# Altium schematic sheet ADC.SchDoc(ADC)
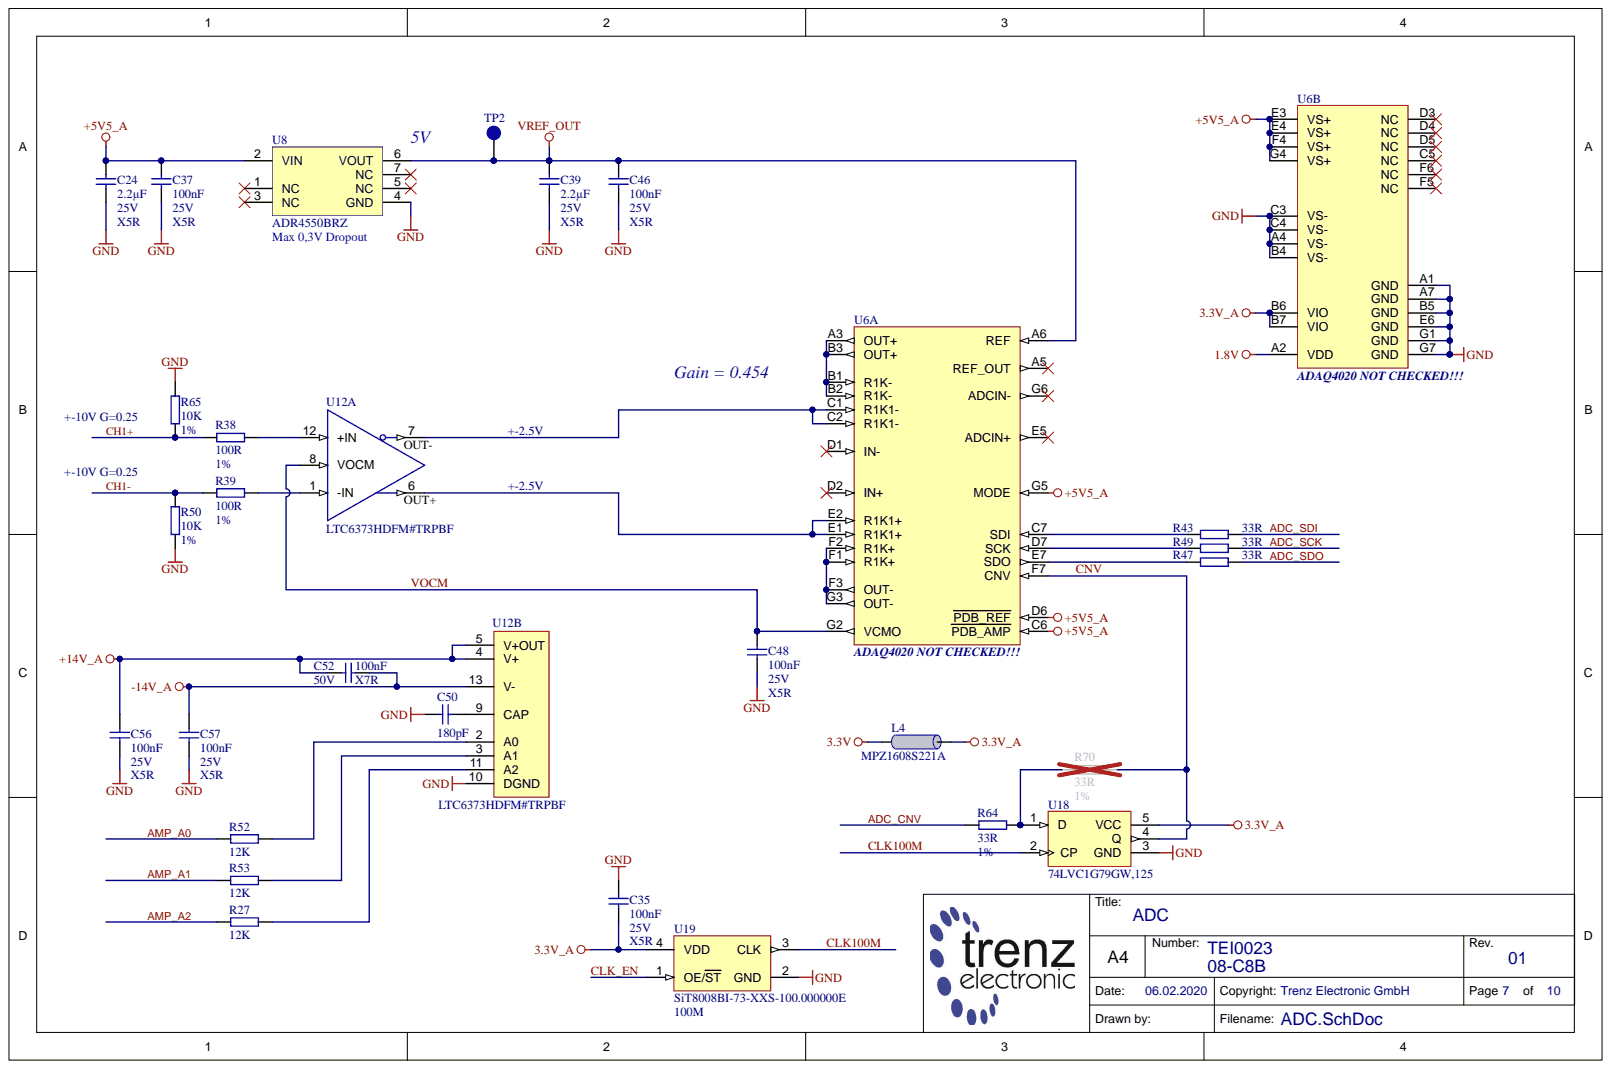
[diagram p0: ADC.SchDoc]

## Parts
C24  2.2µF  Voltage: 25V  Technology: X5R  [CAPC1608X90N]
C35  100nF  Voltage: 25V  [CAPC1005X55N]
C37  100nF  Voltage: 25V  Technology: X5R  [CAPC1005X55N]
C39  2.2µF  Voltage: 25V  Technology: X5R  [CAPC1608X90N]
C46  100nF  Voltage: 25V  Technology: X5R  [CAPC1005X55N]
C48  100nF  Voltage: 25V  Technology: X5R  [CAPC1005X55N]
C50  180pF  [CAPC1005X55N]
C52  100nF  Voltage: 50V  Technology: X7R  [CAPC1005X55N]
C56  100nF  Voltage: 25V  Technology: X5R  [CAPC1005X55N]
C57  100nF  Voltage: 25V  Technology: X5R  [CAPC1005X55N]
L4  MPZ1608S221A  [INDC1608X95N]
R27  12K  [RESC1005X40N]
R38  100R  Tolerance: 1%  [RESC0603X26N]
R39  100R  Tolerance: 1%  [RESC0603X26N]
R43  33R  [RESC1005X40N]
R47  33R  [RESC1005X40N]
R49  33R  [RESC1005X40N]
R50  10K  Tolerance: 1%  [RESC1005X40N]
R52  12K  [RESC1005X40N]
R53  12K  [RESC1005X40N]
R64  33R  Tolerance: 1%  [RESC1005X40N]
R65  10K  Tolerance: 1%  [RESC1005X40N]
R70  33R  [RESC1005X40N]
TP2  Testpoint 0.8mm  [TP_0.8mm]  (value not drawn)
U6  ADAQ4020 NOT CHECKED!!!  [BGA49C80P7X7_700X700X243B45N]  (parts A,B)
U6  ADAQ4020 NOT CHECKED!!!  [BGA49C80P7X7_700X700X243B45N]  (part B)
U8  ADR4550BRZ  Voltage: 5V  [SOIC127P600X175-8N]
U12  LTC6373HDFM#TRPBF  [SON80P400X400X80-13T]  (parts A,B)
U18  74LVC1G79GW,125  [SOT65P210X110-5N]
U19  SiT8008BI-73-XXS-100.000000E  value 100M  Database Table Name: CLK  [2016]

## Nets
1.8V: U6.A2
3.3V: L4.1
3.3V_A: C35.1 L4.2 U6.B6 U6.B7 U18.5(VCC) U19.4(VDD)
+5V5_A: C24.1 C37.1 U6.C6(PDB_AMP) U6.D6(PDB_REF) U6.E3 U6.E4 U6.F4 U6.G4 U6.G5(MODE) U8.2(VIN)
+14V_A: C52.1 C56.1 U12.4(V+) U12.5(V+OUT)
-14V_A: C52.2 C57.1 U12.13(V-)
GND: C24.2 C35.2 C37.2 C39.2 C46.2 C48.2 C50.1 C56.2 C57.2 R50.1 R65.2 U6.A1 U6.A4 U6.A7 U6.B4 U6.B5 U6.C3 U6.C4 U6.E6 U6.G1 U6.G7 U8.4(GND) U12.10(DGND) U18.3(GND) U19.2(GND)
VREF_OUT: C39.1 C46.1 TP2.1 U6.A6(REF) U8.6(VOUT)
ADC_CNV: R64.2
ADC_SCK: R49.2
ADC_SDI: R43.2
ADC_SDO: R47.2
AMP_A0: R52.1
AMP_A1: R53.1
AMP_A2: R27.1
CH1+: R38.1 R65.1
CH1-: R39.1 R50.2
CLK100M: U18.2(CP) U19.3(CLK)
CLK_EN: U19.1(OE/ST)
CNV: R70.1 U6.F7(CNV) U18.4(Q)
VOCM: C48.1 U6.G2(VCMO) U12.8(VOCM)
NetC50_2: C50.2 U12.9(CAP)
NetR27_2: R27.2 U12.11(A2)
NetR38_2: R38.2 U12.12(+IN)
NetR39_2: R39.2 U12.1(-IN)
NetR43_1: R43.1 U6.C7(SDI)
NetR47_1: R47.1 U6.E7(SDO)
NetR49_1: R49.1 U6.D7(SCK)
NetR52_2: R52.2 U12.2(A0)
NetR53_2: R53.2 U12.3(A1)
NetR64_1: R64.1 R70.2 U18.1(D)
NetU6_A3: U6.A3(OUT+) U6.B1(R1K-) U6.B2(R1K-) U6.B3(OUT+)
NetU6_C1: U6.C1(R1K1-) U6.C2(R1K1-) U12.7
NetU6_E1: U6.E1(R1K1+) U6.E2(R1K1+) U12.6
NetU6_F1: U6.F1(R1K+) U6.F2(R1K+) U6.F3(OUT-) U6.G3(OUT-)

Not connected: U6 A5(REF_OUT) C5 D1(IN-) D2(IN+) D3 D4 D5 E5(ADCIN+) F5 F6 G6(ADCIN-); U8 1(NC) 3(NC) 5(NC) 7(NC)

## Library data of the parts
C24 C39 = 27910: KON 2.2uF 25V X5R ±10% SMD BF 1608 (0603); Value: 2.2u; Temperature-Range: -55/+85; Mixed: SMT; Database Table Name: C_1608_0603; Tolerance: ±10%; Library Name: TE.DbLib; Component Kind: Standard; Case: 1608 (0603); AN: 27910
C35 C37 C46 C48 C56 C57 = 22472: KON 100nF 25V X5R ±10% SMD BF 1005 (0402); Value: 100n; Temperature-Range: -55/+85; Preferred: Yes; Mixed: SMT; Database Table Name: C_1005_0402; Library Name: TE.DbLib; Component Kind: Standard; Case: 1005 (0402); AN: 22472
  C35: Technology: X5R
C50 = 24855: KON 180pF 50V NP0 SMD BF 1005 (0402); Value: 180p; Mixed: SMT; Database Table Name: C_1005_0402; Voltage: 50V; Technology: NP0; Library Name: TE.DbLib; Component Kind: Standard; Case: 1005 (0402); AN: 24855
C52 = 22651: KON 100nF 50V X7R ±10% SMD BF 1005 (0402); Value: 100n; Temperature-Range: -55/+125; Mixed: SMT; Database Table Name: C_1005_0402; Tolerance: 10%; Library Name: TE.DbLib; Component Kind: Standard; Case: 1005 (0402); AN: 22651
L4 = 22718: Ferritdurchführung MPZ1608S221A; Value: 220 OHm @ 100MHz; Trenz-Stock: Yes; Mixed: SMT; Database Table Name: L_1608_0603; Preferred: Yes; Library Name: TE.DbLib; H-ART: MPZ1608S221A; Component Kind: Standard; Case: 1608 (0603); AN: 22718; HelpURL: B:\AD\adlib\datasheet\MPZ1608
R27 R52 R53 = 22598: WID SMD 12K 1% 0,063W  BF 1005 (0402); Preferred: Yes; Mixed: SMT; Database Table Name: R_1005_0402; Value: 12K; Tolerance: 1%; Technology: TK100; Library Name: TE.DbLib; Component Kind: Standard; Case: 1005 (0402); AN: 22598
R38 R39 = 22503: WID SMD 100R 1% 0,05W   BF 0603 (0201); Value: 100; Preferred: Yes; Mixed: SMT; Database Table Name: R_0603_0201; Technology: TK100; Library Name: TE.DbLib; Component Kind: Standard; Case: 0603 (0201); AN: 22503
R43 R47 R49 R64 R70 = 22770: WID SMD 33R 1% 0,063W BF 1005 (0402); Value: 33; Preferred: Yes; Mixed: SMT; H-ART: RC0402FR-0733RL; Database Table Name: R_1005_0402; Technology: TK100; Library Name: TE.DbLib; Component Kind: Standard; Case: 1005 (0402); AN: 22770
  R43 R47 R49 R70: Tolerance: 1%
R50 R65 = 22750: WID SMD 10K 1% 0,063W BF 1005 (0402); Preferred: Yes; Mixed: SMT; H-ART: RC0402FR-0710KL; Database Table Name: R_1005_0402; Value: 10K; Technology: TK100; Library Name: TE.DbLib; Component Kind: Standard; Case: 1005 (0402); AN: 22750
TP2 = 26289: Mixed: MECH; Database Table Name: PCB; Library Name: TE.DbLib; Description: Testpoint 0.8mm; Component Kind: Standard; AN: 26289
U6 = 30142: ADAQ4020; Temperature-Range: -40/+125; Mixed: SMT; Database Table Name: ADC; Library Name: TE.DbLib; H-ART: ADAQ4020; Component Kind: Standard; Case: BGA49; AN: 30142; HelpURL: b:\AD\adlib\datasheet\AnalogDevices\ADAQ4003\
U6 = 30142: ADAQ4020; Mixed: SMT; Database Table Name: ADC; Library Name: TE.DbLib; H-ART: ADAQ4020; Component Kind: Standard; Case: BGA49; AN: 30142
U8 = 28288: Mixed: SMT; Database Table Name: REF; Library Name: TE.DbLib; H-ART: ADR4550BRZ; Description: ADR4550BRZ; Component Kind: Standard; Case: SO8; AN: 28288; HelpURL: b:\AD\adlib\datasheet\ADR45xx\
U12 = 30038: Temperature-Range: -40/+125; Mixed: SMT; Database Table Name: OP; Library Name: TE.DbLib; H-ART: LTC6373HDFM#TRPBF; Description: LTC6373HDFM#TRPBF; Component Kind: Standard; Case: DFN12; AN: 30038; HelpURL: B:\AD\adlib\datasheet\AnalogDevices\LTC6373
U18 = 29689: Temperature-Range: -40/+125; Mixed: SMT; Database Table Name: LOGIC; Storage-Temperature: -65/+150(Abs); Library Name: TE.DbLib; H-ART: 74LVC1G79GW,125; Description: 74LVC1G79GW,125; Component Kind: Standard; Case: SC70-5; AN: 29689; HelpURL: b:\AD\adlib\datasheet\74LVC1G79\
U19 = 25961: SiT8008BI-73-XXS-100.000000E MEMS Oszillator 100MHz; Trenz-Stock: Yes; Mixed: SMT; Preferred: Yes; Library Name: TE.DbLib; H-ART: SiT8008BI-73-XXS-100.000000E; Component Kind: Standard; Case: 2.0  x 1.6mm; AN: 25961; HelpURL: B:\AD\adlib\datasheet\SiT8008
